# Altium schematic sheet Main.SchDoc
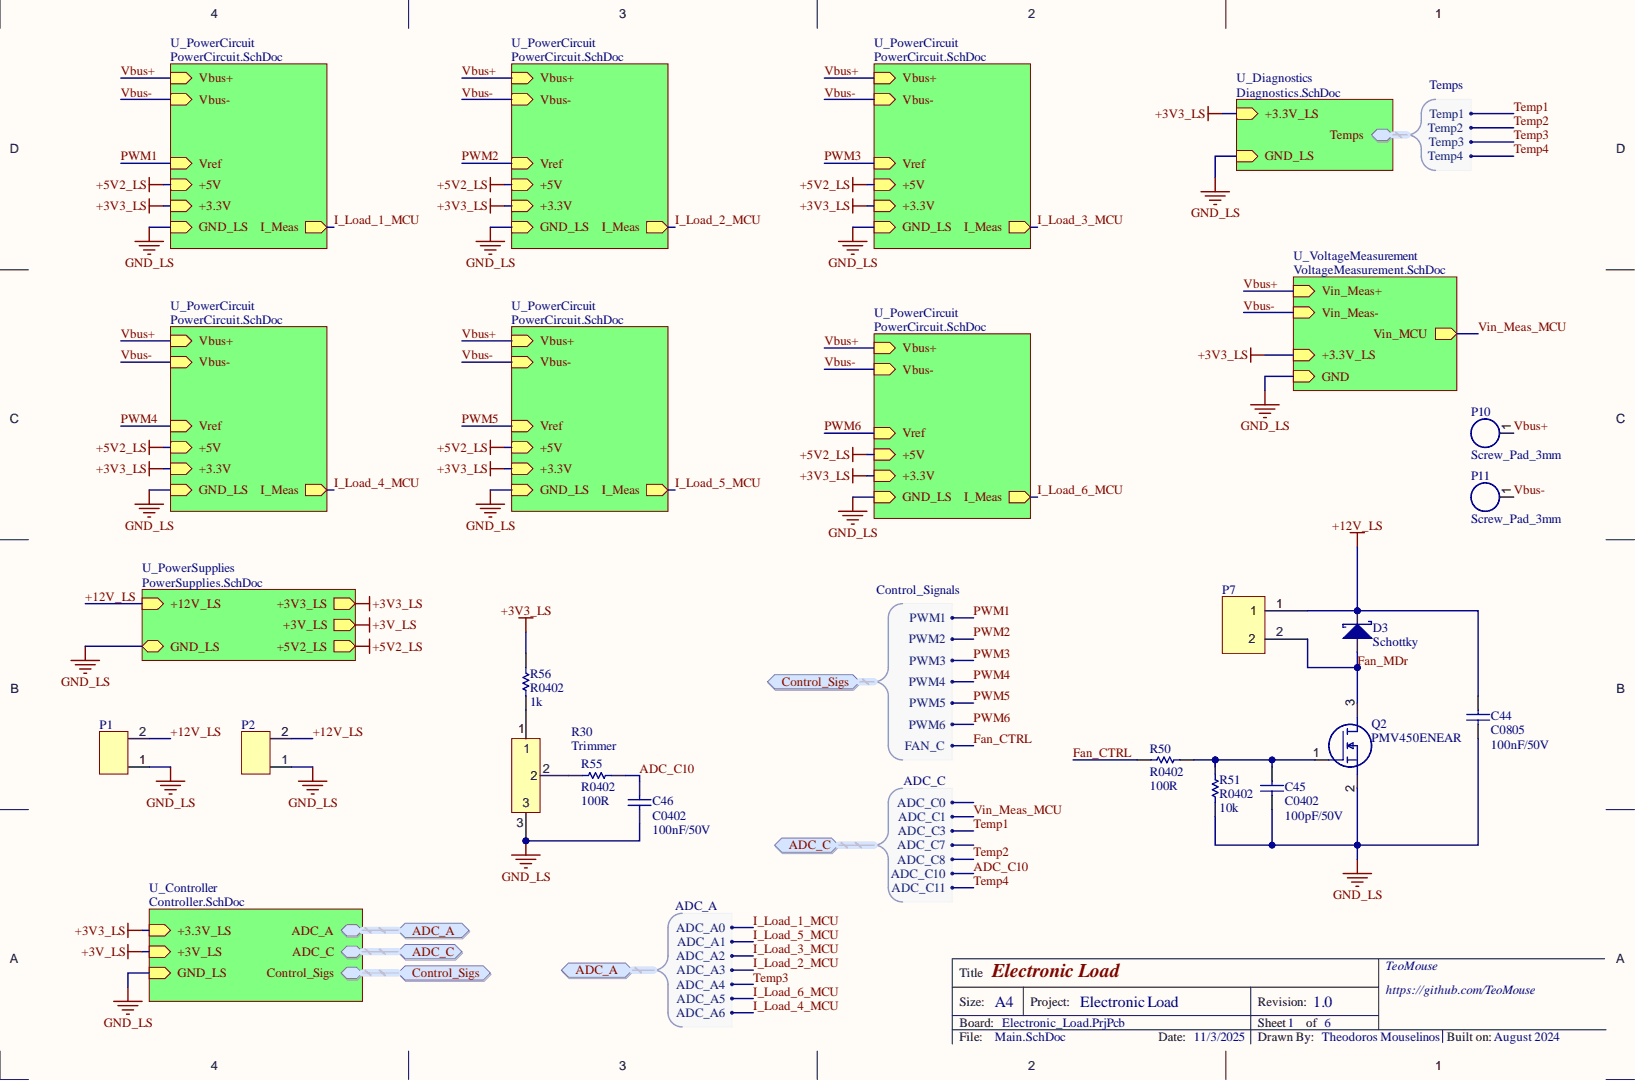
[diagram p0: Main.SchDoc]

## Parts
C44  C0805  value 100nF/50V  [Capacitor 0805]
C45  C0402  value 100pF/50V  [Capacitor 0402]
C46  C0402  value 100nF/50V  [Capacitor 0402]
D3  Schottky  [SOD-123-2]
P1  Con 2x5.08 mm Screw  [2x5.08mm StrCon]  (value not drawn)
P2  Con 2x5.08 mm Screw  [2x5.08mm StrCon]  (value not drawn)
P7  1x2 2.54mm Straight Con  [1x2 2.54mm St_Con]  (value not drawn)
P10  Screw_Pad_3mm  [Screw_Pad]
P11  Screw_Pad_3mm  [Screw_Pad]
Q2  PMV450ENEAR  [SOT-23-3 (TO-236)]
R30  Trimmer  [TRIMMER]
R50  R0402  value 100R  [Resistor 0402]
R51  R0402  value 10k  [Resistor 0402]
R55  R0402  value 100R  [Resistor 0402]
R56  R0402  value 1k  [Resistor 0402]

## Ports
ADC_A, ADC_A.ADC_A0, ADC_A.ADC_A1, ADC_A.ADC_A2, ADC_A.ADC_A3, ADC_A.ADC_A4, ADC_A.ADC_A5, ADC_A.ADC_A6, ADC_C, ADC_C.ADC_C0, ADC_C.ADC_C1, ADC_C.ADC_C3, ADC_C.ADC_C7, ADC_C.ADC_C8, ADC_C.ADC_C10, ADC_C.ADC_C11, Control_Sigs, Control_Sigs.FAN_C, Control_Sigs.PWM1, Control_Sigs.PWM2, Control_Sigs.PWM3, Control_Sigs.PWM4, Control_Sigs.PWM5, Control_Sigs.PWM6

## Nets
+3V3_LS: R56.2
GND_LS: C44.1 C45.1 C46.1 P1.1 P2.1 Q2.2 R30.3 R51.1
+12V_LS: C44.2 D3.1 P1.2 P2.2 P7.1
ADC_C10: C46.2 R55.2 ; port ADC_C
Fan_CTRL: R50.2 ; port Control_Sigs
Fan_MDr: D3.2 P7.2 Q2.3
Vbus+: P10.1
Vbus-: P11.1
NetC45_2: C45.2 Q2.1 R50.1 R51.2
NetR30_1: R30.1 R56.1
NetR30_2: R30.2 R55.1
+3V_LS:
+5V2_LS:
ADC_C.ADC_C0: ; port ADC_C
ADC_C.ADC_C7: ; port ADC_C
I_Load_1_MCU: ; port ADC_A
I_Load_2_MCU: ; port ADC_A
I_Load_3_MCU: ; port ADC_A
I_Load_4_MCU: ; port ADC_A
I_Load_5_MCU: ; port ADC_A
I_Load_6_MCU: ; port ADC_A
PWM1: ; port Control_Sigs
PWM2: ; port Control_Sigs
PWM3: ; port Control_Sigs
PWM4: ; port Control_Sigs
PWM5: ; port Control_Sigs
PWM6: ; port Control_Sigs
Temp1: ; port ADC_C
Temp2: ; port ADC_C
Temp3: ; port ADC_A
Temp4: ; port ADC_C
Vin_Meas_MCU: ; port ADC_C

## Library data of the parts
C44 = C0805: Capacitor; Component Kind: Standard; LatestRevisionDate: 17-Jul-2002; LatestRevisionNote: Re-released for DXP Platform.; Library Name: CommonSchLib.SchLib; PackageDescription: Radial Cap, Thru-Hole; 2 Leads; 0.3 in Pin Spacing; PackageReference: RAD-0.3; Published: 8-Jun-2000; Publisher: Altium Limited
C45 C46 = C0402: Capacitor; Component Kind: Standard; LatestRevisionDate: 17-Jul-2002; LatestRevisionNote: Re-released for DXP Platform.; Library Name: CommonSchLib.SchLib; PackageDescription: Radial Cap, Thru-Hole; 2 Leads; 0.3 in Pin Spacing; PackageReference: RAD-0.3; Published: 8-Jun-2000; Publisher: Altium Limited
D3 = DiodeSchottky SMD: Schottky Diode; Note: Terminal 2 is the TAB and is electrically connected to the cathode; Value: S110FA; Component Kind: Standard; ComponentLink1Description: Manufacturer Link; ComponentLink1URL: http://www.irf.com/; ComponentLink2Description: Datasheet; ComponentLink2URL: http://www.irf.com/product-info/datasheets/data/10tq.pdf; DatasheetVersion: PD-2.057B; LatestRevisionDate: 17-Jul-2002; LatestRevisionNote: Re-released for DXP Platform.; Library Name: CommonSchLib.SchLib; PackageDescription: TO, Thru-Hole, Vertical, Heatsink Mounted; 3 In-Line Leads (1 Absent); Pitch 2.54 mm; PackageReference: TO-220AC; PackageVersion: Feb-1998; Published: 8-Jun-2000; Supplier 1: Mouser; Supplier Part Number 1: 512-S110FA; Publisher: Altium Limited
Q2 = PMV450ENEAR: MOSFET (N-Channel); Manufacturer_Name: Infineon; Manufacturer_Part_Number: IPP023N08N5AKSA1; Component Kind: Standard; Datasheet Link: https://gr.mouser.com/datasheet/2/196/Infineon-IPP023N08N5-DS-v02_00-EN-1227118.pdf; Height: 4.57mm; Library Name: CommonSchLib.SchLib; Mouser2 Part Number: 726-IPP023N08N5AKSA1; Mouser2 Price/Stock: https://gr.mouser.com/ProductDetail/Infineon-Technologies/IPP023N08N5AKSA1?qs=%2Fha2pyFadujhW4Bob9P1cPVJ46syk6m574copaISrTUILyyC9SOcdJiVP5ogk9W1; Supplier 1: Mouser; Supplier Part Number 1: 771-PMV450ENEAR
R50 R51 R56 = R0402: Resistor; Component Kind: Standard; LatestRevisionDate: 17-Jul-2002; LatestRevisionNote: Re-released for DXP Platform.; Library Name: CommonSchLib.SchLib; PackageDescription: Axial Device, Thru-Hole; 2 Leads; 0.3 in Pin Spacing; PackageReference: AXIAL-0.3; Published: 8-Jun-2000; Publisher: Altium Limited
R55 = R0402: Resistor; Value: 100R; Component Kind: Standard; LatestRevisionDate: 17-Jul-2002; LatestRevisionNote: Re-released for DXP Platform.; Library Name: CommonSchLib.SchLib; PackageDescription: Axial Device, Thru-Hole; 2 Leads; 0.3 in Pin Spacing; PackageReference: AXIAL-0.3; Published: 8-Jun-2000; Publisher: Altium Limited
P1 P2 = Con 2x5.08 mm Screw: Component Kind: Standard; Library Name: CommonSchLib.SchLib
P7 = 1x2 2.54mm Straight Con: Component Kind: Standard; Library Name: CommonSchLib.SchLib
P10 P11 = Screw_Pad_3mm: Component Kind: Standard; Library Name: CommonSchLib.SchLib
R30 = Trimmer: Component Kind: Standard; Library Name: CommonSchLib.SchLib
